# Altium schematic sheet FGN410_MCU.SchDoc
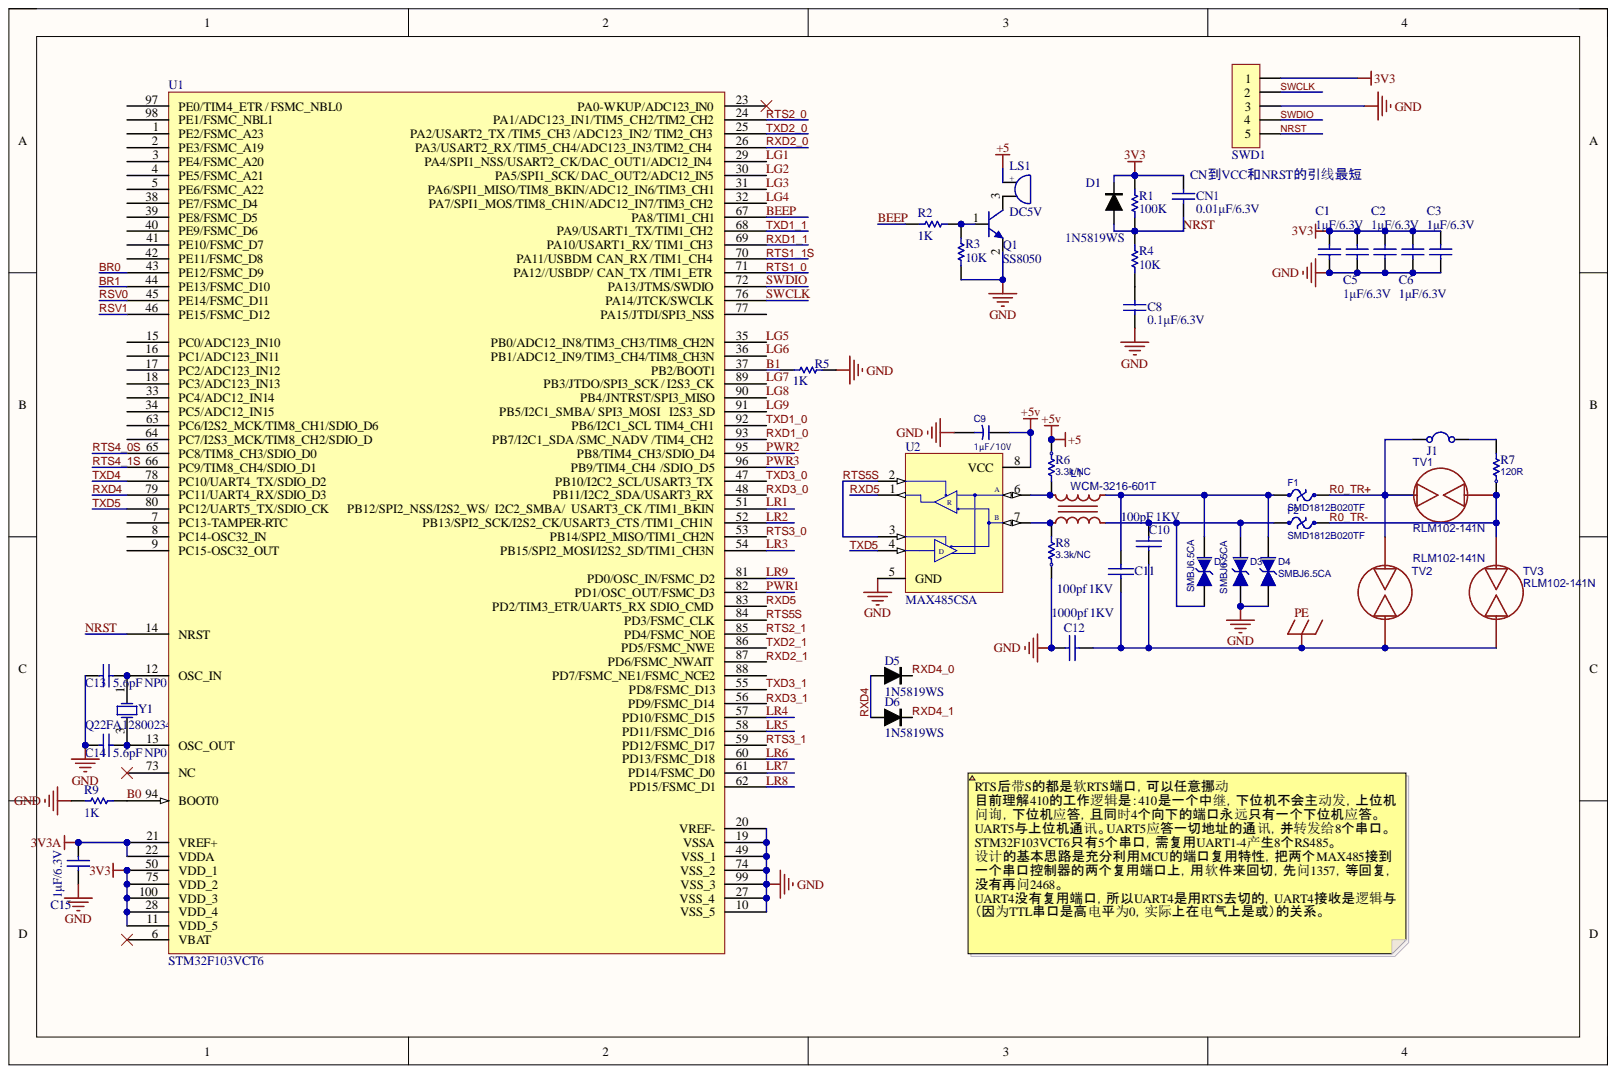
[diagram p0: FGN410_MCU.SchDoc]

## Parts
C1  1μF/6.3V  [0603_C]
C2  1μF/6.3V  [0603_C]
C3  1μF/6.3V  [0603_C]
C5  1μF/6.3V  [0603_C]
C6  1μF/6.3V  [0603_C]
C8  0.1μF/6.3V  [0603_C]
C9  1μF/10V  [0603_C]
C10  100pF 1KV  [C1206]
C11  100pf 1KV  [C1206]
C12  1000pf 1KV  [C1206]
C13  5.6pF NP0  [0603_C]
C14  5.6pF NP0  [0603_C]
C15  1μF/6.3V  [0603_C]
CN1  0.01μF/6.3V  [0603_C]
D1  1N5819WS  [SOD-323]
D2  SMBJ6.5CA  [SMB]
D3  SMBJ6.5CA  [SMB]
D4  SMBJ6.5CA  [SMB]
D5  1N5819WS  [SOD-323]
D6  1N5819WS  [SOD-323]
F1  SMD1812B020TF  [F1812]
F2  SMD1812B020TF  [F1812]
J1  JP  [SIP2-2.54]  (value not drawn)
L1  WCM-3216-601T  [FILTER-SMD_4P-L3.2-W1.6-BL]
LS1  DC5V  [BEEP 5x9x5.5]
Q1  SS8050  [SOT23-3N]
R1  100K  [0603_R]
R2  1K  [0603_R]
R3  10K  [0603_R]
R4  10K  [0603_R]
R5  1K  [0603_R]
R6  3.3k/NC  [0603_R]
R7  120R  [0603_R]
R8  3.3k/NC  [0603_R]
R9  1K  [0603_R]
SWD1  HDR-1X5  [HDR2.54-LI-5P]  (value not drawn)
TV1  RLM102-141N  [DIO-DT-SMD_L5.0-W2.8]
TV2  RLM102-141N  [DIO-DT-SMD_L5.0-W2.8]
TV3  RLM102-141N  [DIO-DT-SMD_L5.0-W2.8]
U1  STM32F103VCT6  [LQFP100 14x14_M]
U2  MAX485CSA  [SOP8]
Y1  Q22FA12800234  [OSC 3225-4P]

## Nets
3V3: C1.2 C2.2 C3.2 C5.2 C6.2 CN1.1 D1.1 R1.2 SWD1.1 U1.11(VDD_5) U1.28(VDD_4) U1.50(VDD_1) U1.75(VDD_2) U1.100(VDD_3)
3V3A: C15.2 U1.21(VREF+) U1.22(VDDA)
+5: C9.1 LS1.1 R6.1 U2.8(VCC)
GND: C1.1 C2.1 C3.1 C5.1 C6.1 C8.2 C9.2 C12.1 C13.2 C14.1 C15.1 D3.1 D4.1 Q1.2 R3.2 R5.1 R8.1 R9.2 SWD1.3 U1.10(VSS_5) U1.19(VSSA) U1.20(VREF-) U1.27(VSS_4) U1.49(VSS_1) U1.74(VSS_2) U1.99(VSS_3) U2.5(GND)
PE: C10.2 C11.2 C12.2 TV2.1 TV3.1
B0: R9.1 U1.94(BOOT0)
B1: R5.2 U1.37(PB2/BOOT1)
BEEP: R2.2 U1.67(PA8/TIM1_CH1)
BR0: U1.43(PE12/FSMC_D9)
BR1: U1.44(PE13/FSMC_D10)
LG1: U1.29(PA4/SPI1_NSS/USART2_CK/DAC_OUT1/ADC12_IN4)
LG2: U1.30(PA5/SPI1_SCK/_DAC_OUT2/ADC12_IN5)
LG3: U1.31(PA6/SPI1_MISO/TIM8_BKIN/ADC12_IN6/TIM3_CH1)
LG4: U1.32(PA7/SPI1_MOS/TIM8_CH1N/ADC12_IN7/TIM3_CH2)
LG5: U1.35(PB0/ADC12_IN8/TIM3_CH3/TIM8_CH2N)
LG6: U1.36(PB1/ADC12_IN9/TIM3_CH4/TIM8_CH3N)
LG7: U1.89(PB3/JTDO/SPI3_SCK_/_I2S3_CK)
LG8: U1.90(PB4/JNTRST/SPI3_MISO)
LG9: U1.91(I2S3_SD)
LR1: U1.51(USART3_CK_/TIM1_BKIN)
LR2: U1.52(PB13/SPI2_SCK/I2S2_CK/USART3_CTS_/TIM1_CH1N)
LR3: U1.54(PB15/SPI2_MOSI/I2S2_SD/TIM1_CH3N)
LR4: U1.57(PD10/FSMC_D15)
LR5: U1.58(PD11/FSMC_D16)
LR6: U1.60(PD13/FSMC_D18)
LR7: U1.61(PD14/FSMC_D0)
LR8: U1.62(PD15/FSMC_D1)
LR9: U1.81(PD0/OSC_IN/FSMC_D2)
NRST: CN1.2 D1.2 R1.1 R4.2 SWD1.5 U1.14(NRST)
PWR1: U1.82(PD1/OSC_OUT/FSMC_D3)
PWR2: U1.95(PB8/TIM4_CH3/SDIO_D4)
PWR3: U1.96(PB9/TIM4_CH4_/SDIO_D5)
R0_TR+: F1.2 J1.1 TV1.2 TV2.2
R0_TR-: F2.2 R7.1 TV1.1 TV3.2
RSV0: U1.45(PE14/FSMC_D11)
RSV1: U1.46(PE15/FSMC_D12)
RTS1_0: U1.71(PA12//USBDP/_CAN_TX_/TIM1_ETR)
RTS1_1S: U1.70(PA11/USBDM_CAN_RX_/TIM1_CH4)
RTS2_0: U1.24(PA1/ADC123_IN1/TIM5_CH2/TIM2_CH2)
RTS2_1: U1.85(PD4/FSMC_NOE)
RTS3_0: U1.53(PB14/SPI2_MISO/TIM1_CH2N)
RTS3_1: U1.59(PD12/FSMC_D17)
RTS4_0S: U1.65(PC8/TIM8_CH3/SDIO_D0)
RTS4_1S: U1.66(PC9/TIM8_CH4/SDIO_D1)
RTS5S: U1.84(PD3/FSMC_CLK) U2.2 U2.3
RXD1_0: U1.93(PB7/I2C1_SDA_/SMC_NADV_/TIM4_CH2)
RXD1_1: U1.69(PA10/USART1_RX/_TIM1_CH3)
RXD2_0: U1.26(PA3/USART2_RX_/TIM5_CH4/ADC123_IN3/TIM2_CH4)
RXD2_1: U1.87(PD6/FSMC_NWAIT)
RXD3_0: U1.48(PB11/I2C2_SDA/USART3_RX)
RXD3_1: U1.56(PD9/FSMC_D14)
RXD4: D5.2 D6.2 U1.79(PC11/UART4_RX/SDIO_D3)
RXD4_0: D5.1
RXD4_1: D6.1
RXD5: U1.83(PD2/TIM3_ETR/UART5_RX_SDIO_CMD) U2.1
SWCLK: SWD1.2 U1.76(PA14/JTCK/SWCLK)
SWDIO: SWD1.4 U1.72(PA13/JTMS/SWDIO)
TXD1_0: U1.92(PB6/I2C1_SCL_TIM4_CH1)
TXD1_1: U1.68(PA9/USART1_TX/TIM1_CH2)
TXD2_0: U1.25(PA2/USART2_TX_/TIM5_CH3_/ADC123_IN2/_TIM2_CH3)
TXD2_1: U1.86(PD5/FSMC_NWE)
TXD3_0: U1.47(PB10/I2C2_SCL/USART3_TX)
TXD3_1: U1.55(PD8/FSMC_D13)
TXD4: U1.78(PC10/UART4_TX/SDIO_D2)
TXD5: U1.80(PC12/UART5_TX/SDIO_CK) U2.4
NetC8_1: C8.1 R4.1
NetC10_1: C10.1 D2.1 D3.2 F2.1 L1.3
NetC11_1: C11.1 D2.2 D4.2 F1.1 L1.4
NetC13_1: C13.1 U1.12(OSC_IN) Y1.1
NetC14_2: C14.2 U1.13(OSC_OUT) Y1.3
NetJ1_2: J1.2 R7.2
NetL1_1: L1.1 R6.2 U2.6
NetL1_2: L1.2 R8.2 U2.7
NetLS1_2: LS1.2 Q1.3
NetQ1_1: Q1.1 R2.1 R3.1

Not connected: U1 1(PE2/FSMC_A23) 2(PE3/FSMC_A19) 3(PE4/FSMC_A20) 4(PE5/FSMC_A21) 5(PE6/FSMC_A22) 6(VBAT) 7(PC13-TAMPER-RTC) 8(PC14-OSC32_IN) 9(PC15-OSC32_OUT) 15(PC0/ADC123_IN10) 16(PC1/ADC123_IN11) 17(PC2/ADC123_IN12) 18(PC3/ADC123_IN13) 23(PA0-WKUP/ADC123_IN0) 33(PC4/ADC12_IN14) 34(PC5/ADC12_IN15) 38(PE7/FSMC_D4) 39(PE8/FSMC_D5) 40(PE9/FSMC_D6) 41(PE10/FSMC_D7) 42(PE11/FSMC_D8) 63(PC6/I2S2_MCK/TIM8_CH1/SDIO_D6) 64(PC7/I2S3_MCK/TIM8_CH2/SDIO_D) 73(NC) 77(PA15/JTDI/SPI3_NSS) 88(PD7/FSMC_NE1/FSMC_NCE2) 97(PE0/TIM4_ETR_/_FSMC_NBL0) 98(PE1/FSMC_NBL1)

## Library data of the parts
C1-C3 C5 C6 C8 C15 CN1 = 0603 1uF (105) 10% 50V: 贴片电容; Component Kind: Standard; ComponentLink1Description: 供应商链接; ComponentLink1URL: http://www.szlcsc.com/product/details_16531.html; Library Name: Cap.SchLib; Package: 0603; Supplier: LC; SuppliersPartNumber: C15849
C9 = Cap: Capacitor; Component Kind: Standard; Published: 23-Sep-2002; Signal Integrity: Cap; Simulation: CAP; Value: 1μF/10V; Library Name: zzt.SchLib; Publisher: Altium Limited
C10-C12 = CAP: Capacitor; Component Kind: Standard; Library Name: 杂元件库.SCHLIB
C13 C14 = 0402 56pF (560) 5% 50V: 贴片电容; Component Kind: Standard; ComponentLink1Description: 供应商链接; ComponentLink1URL: http://www.szlcsc.com/product/details_1924.html; Library Name: Cap.SchLib; Package: 0402; Supplier: LC; SuppliersPartNumber: C1572
D1 D5 D6 = DIODE_1N4148W: Component Kind: Standard; Library Name: My_Int_Library.IntLib
D2-D4 = TVS: Code_JEDEC: DO-214-AB; Component Kind: Standard; Description: TVS; LatestRevisionDate: 17-Jul-2002; LatestRevisionNote: Re-released for DXP Platform.; Published: 8-Jun-2000; Signal Integrity: Diode; Simulation: DIODE; Library Name: zzt.SchLib; Publisher: Altium Limited
F1 F2 = Fuse Thermal: Thermal Fuse; Component Kind: Standard; LatestRevisionDate: 17-Jul-2002; LatestRevisionNote: Re-released for DXP Platform.; PackageReference: PIN-W2/E2.8; Published: 24-Mar-1999; Library Name: zzt.SchLib; Publisher: Altium Limited
J1 = JMP: Component Kind: Standard; Library Name: My_Int_Library.IntLib
L1 = PCAQ1211FA-202: BOM_Manufacturer: PROD Tech; Contributor: LCSC; BOM_Manufacturer Part: PCAQ1211FA-202; BOM_Supplier: LCSC; BOM_Supplier Part: C784889; Component Kind: Standard; spicePre: L; spiceSymbolName: PCAQ1211FA-202
LS1 = BEEP: 蜂鸣器; 版权归属: 源创客; BOM名称: 蜂鸣器; Component Kind: Standard; Library Name: 未分类器件.SchLib; 学习资源: www.ooocke.com
Q1 = 8050-SMD: 高频放大-NPN型; 属性: 40V 1.5A 1W 100MHZ; 版权归属: 源创客; BOM名称: 高频放大-NPN型; Component Kind: Standard; Library Name: 三极管.SchLib; 学习资源: www.ooocke.com
R1-R5 R9 = Res1: Resistor; Power: 1/4W; Precision: ±1%; Component Kind: Standard; Library Name: Miscellaneous Devices.IntLib
  R1: Value: 100K
  R2 R5 R9: Value: 1K
  R3 R4: Value: 10K
R6-R8 = Res: Resistor; Component Kind: Standard; LatestRevisionDate: 17-Jul-2002; LatestRevisionNote: Re-released for DXP Platform.; Published: 8-Jun-2000; Signal Integrity: Res; Simulation: RESISTOR; Library Name: zzt.SchLib; Publisher: Altium Limited
  R6 R8: Value: 3.3k/NC
  R7: Value: 120R
SWD1 = Header 5: 5P接插件; 版权归属: 源创客; BOM名称: 5P接插件; Component Kind: Standard; Library Name: 接插件-脚距2.54.SchLib; 学习资源: www.ooocke.com
TV1-TV3 = 4532-091-LF: BOM_Manufacturer: Brightking; BOM_JLCPCB Part Class: Extended Part; BOM_Manufacturer Part: 4532-091-LF; BOM_Supplier: LCSC; BOM_Supplier Part: C78323; Component Kind: Standard; spicePre: T; spiceSymbolName: 4532-091-LF
U2 = MAX485CSA: Low-Power, Slew-Rate-Limited RS-485/RS-422 Transceiver; Code_IPC: SOIC127P600-8; Code_JEDEC: MS-012-AA; Component Kind: Standard; ComponentLink1Description: Manufacturer Link; ComponentLink1URL: http://www.maxim-ic.com/; ComponentLink2Description: Datasheet; ComponentLink2URL: http://pdfserv.maxim-ic.com/en/ds/MAX1487-MAX491.pdf; DatasheetVersion: Feb-1996; LatestRevisionDate: 13-Apr-2006; LatestRevisionNote: IPC-7351 Footprint Added.; Library Name: Maxim Communication Transceiver.IntLib; PackageDescription: 8-Pin Small Outline Integrated Circuit 1.27 mm Pitch; PackageReference: NSO8; PCB3D: NSO8; Published: 16-Jan-2001; Publisher: Altium Limited
Y1 = SMD-3225_4P  16MHZ 9PF 10PPM: 贴片晶振; Component Kind: Standard; ComponentLink1Description: 供应商链接; ComponentLink1URL: http://www.szlcsc.com/product/details_14393.html; Library Name: CrystalOscillator.SchLib; Package: SMD-3225_4P; Supplier: LC; SuppliersPartNumber: C13738
U1 = STM32F103VCT6: Component Kind: Standard; Library Name: My_Int_Library.IntLib; Value: STM32F103VCT6
